# Altium schematic sheet AD936X_Power.SchDoc(AD936X_Power)
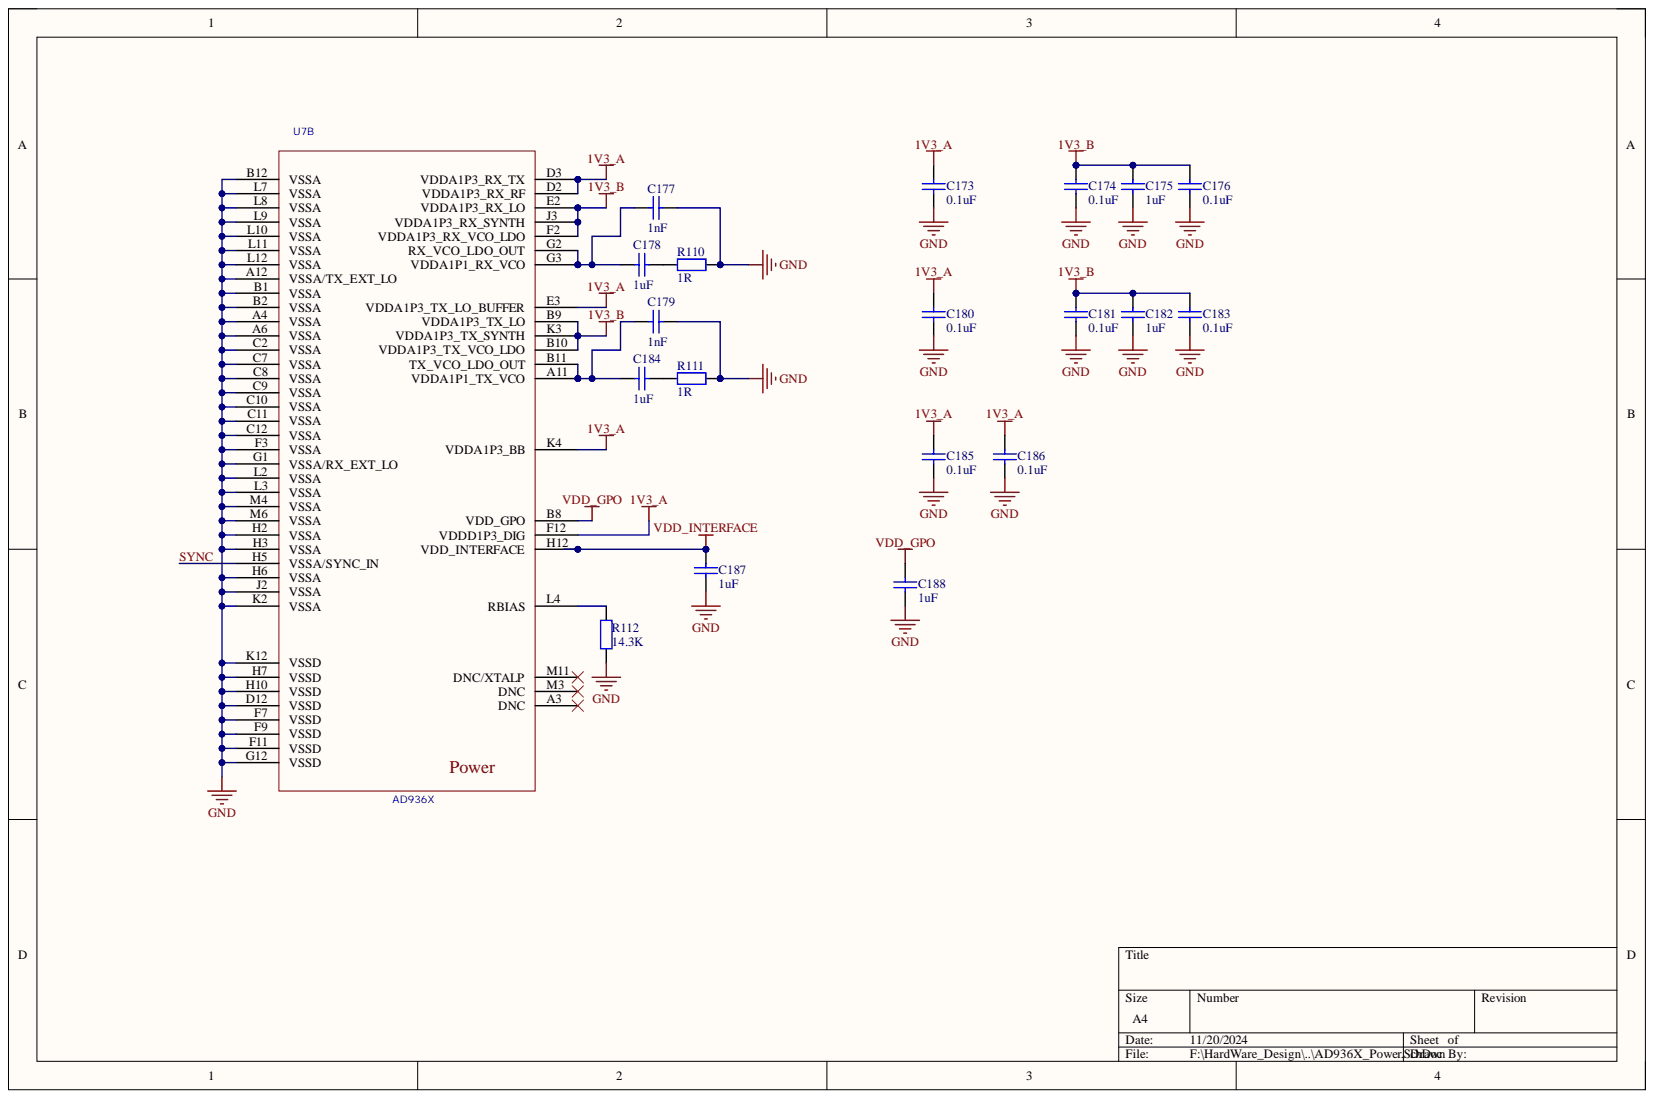
[diagram p0: AD936X_Power.SchDoc]

## Parts
C173  0.1uF  [C 0402_L]
C174  0.1uF  [C 0402_L]
C175  1uF  [C 0402_L]
C176  0.1uF  [C 0402_L]
C177  1nF  [C 0402_L]
C178  1uF  [C 0402_L]
C179  1nF  [C 0402_L]
C180  0.1uF  [C 0402_L]
C181  0.1uF  [C 0402_L]
C182  1uF  [C 0402_L]
C183  0.1uF  [C 0402_L]
C184  1uF  [C 0402_L]
C185  0.1uF  [C 0402_L]
C186  0.1uF  [C 0402_L]
C187  1uF  [C 0402_L]
C188  1uF  [C 0402_L]
R110  1R  [R 0402_L]
R111  1R  [R 0402_L]
R112  14.3K  [R 0402_L]
U7  AD936X  [BGA144C80P12X12_1000X1000X170]  (part B)

## Nets
1V3_A: C173.2 C180.2 C185.2 C186.2 U7.D2(VDDA1P3_RX_RF) U7.D3(VDDA1P3_RX_TX) U7.E3(VDDA1P3_TX_LO_BUFFER) U7.F12(VDDD1P3_DIG) U7.K4(VDDA1P3_BB)
1V3_B: C174.2 C175.2 C176.2 C181.2 C182.2 C183.2 U7.B9(VDDA1P3_TX_LO) U7.B10(VDDA1P3_TX_VCO_LDO) U7.E2(VDDA1P3_RX_LO) U7.F2(VDDA1P3_RX_VCO_LDO) U7.J3(VDDA1P3_RX_SYNTH) U7.K3(VDDA1P3_TX_SYNTH)
GND: C173.1 C174.1 C175.1 C176.1 C177.2 C179.2 C180.1 C181.1 C182.1 C183.1 C185.1 C186.1 C187.1 C188.1 R110.2 R111.2 R112.1 U7.A4(VSSA) U7.A6(VSSA) U7.A12(VSSA/TX_EXT_LO) U7.B1(VSSA) U7.B2(VSSA) U7.B12(VSSA) U7.C2(VSSA) U7.C7(VSSA) U7.C8(VSSA) U7.C9(VSSA) U7.C10(VSSA) U7.C11(VSSA) U7.C12(VSSA) U7.D12(VSSD) U7.F3(VSSA) U7.F7(VSSD) U7.F9(VSSD) U7.F11(VSSD) U7.G1(VSSA/RX_EXT_LO) U7.G12(VSSD) U7.H2(VSSA) U7.H3(VSSA) U7.H6(VSSA) U7.H7(VSSD) U7.H10(VSSD) U7.J2(VSSA) U7.K2(VSSA) U7.K12(VSSD) U7.L2(VSSA) U7.L3(VSSA) U7.L7(VSSA) U7.L8(VSSA) U7.L9(VSSA) U7.L10(VSSA) U7.L11(VSSA) U7.L12(VSSA) U7.M4(VSSA) U7.M6(VSSA)
VDD_GPO: C188.2 U7.B8(VDD_GPO)
VDD_INTERFACE: C187.2 U7.H12(VDD_INTERFACE)
SYNC: U7.H5(VSSA/SYNC_IN)
NetC177_1: C177.1 C178.1 U7.G2(RX_VCO_LDO_OUT) U7.G3(VDDA1P1_RX_VCO)
NetC178_2: C178.2 R110.1
NetC179_1: C179.1 C184.1 U7.A11(VDDA1P1_TX_VCO) U7.B11(TX_VCO_LDO_OUT)
NetC184_2: C184.2 R111.1
NetR112_2: R112.2 U7.L4(RBIAS)

Not connected: U7 A3(DNC) M3(DNC) M11(DNC/XTALP)

## Library data of the parts
C173-C188 = Cap: 贴片电容; Component Kind: Standard; Library Name: A_Schlib.SchLib
  C173 C174 C176 C180 C181 C183 C185 C186: value: 0.1uF
  C175 C178 C182 C184 C187 C188: value: 1uF
  C177 C179: value: 1nF
R110-R112 = Res: 通用; Component Kind: Standard; Library Name: A_Schlib.SchLib
  R110 R111: value: 1R
  R112: value: 14.3K
U7 = AD936X: 射频捷变收发器; Component Kind: Standard; Library Name: A_Schlib.SchLib
